# Altium schematic sheet FPGA_Connectors.SchDoc
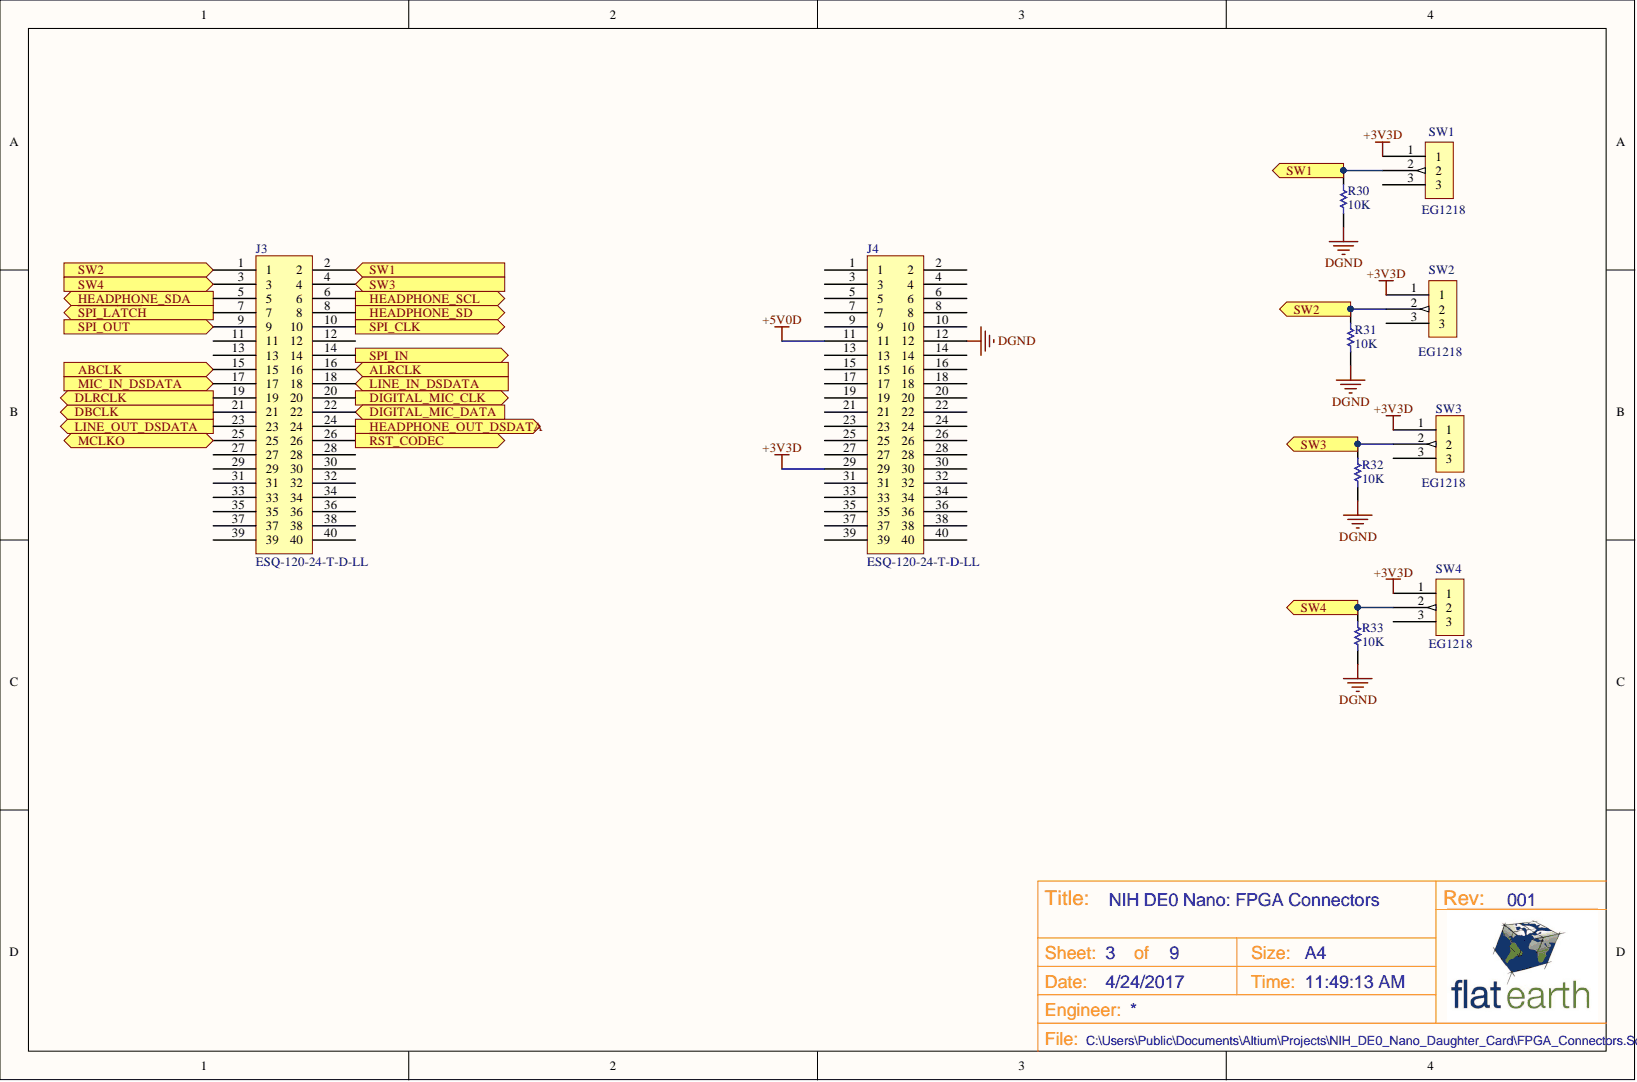
[diagram p0: FPGA_Connectors.SchDoc]

## Parts
J3  ESQ-120-24-T-D-LL  [ESQ-120-24-T-D-LL]
J4  ESQ-120-24-T-D-LL  [ESQ-120-24-T-D-LL]
R30  Resistance (Ohms): 10K  [3-0603_R_L]  (hidden comment: ERJ-3GEYJ103V)
R31  Resistance (Ohms): 10K  [3-0603_R_L]  (hidden comment: ERJ-3GEYJ103V)
R32  Resistance (Ohms): 10K  [3-0603_R_L]  (hidden comment: ERJ-3GEYJ103V)
R33  Resistance (Ohms): 10K  [3-0603_R_L]  (hidden comment: ERJ-3GEYJ103V)
SW1  Slide Switch SPDT Through Hole  [EG1218]  (value not drawn)
SW2  Slide Switch SPDT Through Hole  [EG1218]  (value not drawn)
SW3  Slide Switch SPDT Through Hole  [EG1218]  (value not drawn)
SW4  Slide Switch SPDT Through Hole  [EG1218]  (value not drawn)

## Ports
ABCLK, ALRCLK, DBCLK, DIGITAL_MIC_CLK, DIGITAL_MIC_DATA, DLRCLK, HEADPHONE_OUT_DSDATA, HEADPHONE_SCL, HEADPHONE_SD, HEADPHONE_SDA, LINE_IN_DSDATA, LINE_OUT_DSDATA, MCLKO, MIC_IN_DSDATA, SPI_CLK, SPI_IN, SPI_OUT, SW1, SW2, SW3, SW4, ~{RST_CODE}C, ~{SPI_LATCH}

## Nets
+3V3D: J4.29 SW1.1 SW2.1 SW3.1 SW4.1
+5V0D: J4.11
DGND: J4.12 R30.2 R31.2 R32.2 R33.2
~{RST_CODE}C: J3.26 ; port ~{RST_CODE}C
ABCLK: J3.15 ; port ABCLK
ALRCLK: J3.16 ; port ALRCLK
DBCLK: J3.21 ; port DBCLK
DIGITAL_MIC_CLK: J3.20 ; port DIGITAL_MIC_CLK
DIGITAL_MIC_DATA: J3.22 ; port DIGITAL_MIC_DATA
DLRCLK: J3.19 ; port DLRCLK
HEADPHONE_OUT_DSDATA: J3.24 ; port HEADPHONE_OUT_DSDATA
HEADPHONE_SCL: J3.6 ; port HEADPHONE_SCL
HEADPHONE_SD: J3.8 ; port HEADPHONE_SD
HEADPHONE_SDA: J3.5 ; port HEADPHONE_SDA
LINE_IN_DSDATA: J3.18 ; port LINE_IN_DSDATA
LINE_OUT_DSDATA: J3.23 ; port LINE_OUT_DSDATA
MCLKO: J3.25 ; port MCLKO
MIC_IN_DSDATA: J3.17 ; port MIC_IN_DSDATA
~{SPI_LATCH}: J3.7 ; port ~{SPI_LATCH}
SPI_CLK: J3.10 ; port SPI_CLK
SPI_IN: J3.14 ; port SPI_IN
SPI_OUT: J3.9 ; port SPI_OUT
SW1: J3.2 R30.1 SW1.2 ; port SW1
SW2: J3.1 R31.1 SW2.2 ; port SW2
SW3: J3.4 R32.1 SW3.2 ; port SW3
SW4: J3.3 R33.1 SW4.2 ; port SW4

Not connected: J3 11 12 13 27 28 29 30 31 32 33 34 35 36 37 38 39 40; J4 1 2 3 4 5 6 7 8 9 10 13 14 15 16 17 18 19 20 21 22 23 24 25 26 27 28 30 31 32 33 34 35 36 37 38 39 40; SW1 3; SW2 3; SW3 3; SW4 3

## Library data of the parts
J3 J4 = CON_TH_0D100P_2x20: Female to Male 0D100P 2x20 Connector; Manufacturer: Samtec; Manufacturer Part Number: ESQ-120-44-T-D-LL; Component Kind: Standard; LatestRevisionDate: 17-Jul-2002; LatestRevisionNote: Re-released for DXP Platform.; Supplier 1: Samtec; Supplier Part Number 1: ESQ-120-44-T-D-LL; Library Name: NIH_DE0_Nano_Daughter_Card_Library.SchLib; Publisher: Altium Limited
R30-R33 = ERJ-3GEYJ103V: RES 10K OHM 1/10W 5% 0603 SMD; Manufacturer: Panasonic - ECG; Power (Watts): 0.1W, 1/10W; Temperature Coefficient: ±200ppm/°C; Category: Resistors; Component Kind: Standard; ComponentLink1Description: http://industrial.panasonic.com/www-cgi/jvcr13pz.cgi?E+PZ+3+AOA0001+ERJ3GEYJ103V+7+WW; ComponentLink1URL: http://industrial.panasonic.com/www-cgi/jvcr13pz.cgi?E+PZ+3+AOA0001+ERJ3GEYJ103V+7+WW; Composition: Thick Film; Features: -; Height: 0.022" (0.55mm); Library Name: Schematic_Components.SchLib; Manufacturer Part Number: ERJ-3GEYJ103V; Number of Terminations: 2; Package / Case: 0603 (1608 Metric); Packaging: Cut Tape (CT); Pricing 1: 1=0.02, 50=0.0092, 100=0.007, 250=0.0052, 500=0.00384, 1000=0.00256 (USD); RoHS: RoHS Compliant; Size / Dimension: 0.063" L x 0.031" W (1.60mm x 0.80mm); Stock 1: 16219504; Supplier 1: Digi-Key; Supplier Part Number 1: P10KGCT-ND; Tolerance: ±5%
SW1-SW4 = EG1218: Manufacturer: E-Switch; Actuator Length: 2.00mm; Actuator Type: Standard; Contact Timing: Non-Shorting (BBM); Current Rating: 200mA (DC); Operating Force: 250gf; Switch Function: On-On; Switch Travel: 2.50mm; Voltage Rating - DC: 30V; Actuator Material: -; Category: Switches; Circuit: SPDT; Component Kind: Standard; ComponentLink1Description: http://spec_sheets.e-switch.com/specs/P040040.pdf; ComponentLink1URL: http://spec_sheets.e-switch.com/specs/P040040.pdf; Contact Finish: Silver; Contact Material: Copper Alloy; Description: Slide Switch SPDT Through Hole; Electrical Life: -; Features: -; LatestRevisionDate: 17-Jul-2002; LatestRevisionNote: Re-released for DXP Platform.; Manufacturer Part Number: EG1218; Mechanical Life: -; Mounting Type: Through Hole; Operating Temperature: -20°C ~ 70°C; Packaging: Bulk; Part Status: Active; Pricing 1: 1=0.58, 10=0.559, 25=0.5476, 50=0.4894, 100=0.466, 250=0.45436, 500=0.38446, 1000=0.3728 (USD); RoHS: RoHS Compliant; Stock 1: 22149; Supplier 1: Digi-Key; Supplier Part Number 1: EG1903-ND; Termination Style: PC Pin; Voltage Rating - AC: -; Library Name: NIH_DE0_Nano_Daughter_Card_Library.SchLib; Publisher: Altium Limited
